# Altium schematic sheet RC96_Tone.SchDoc
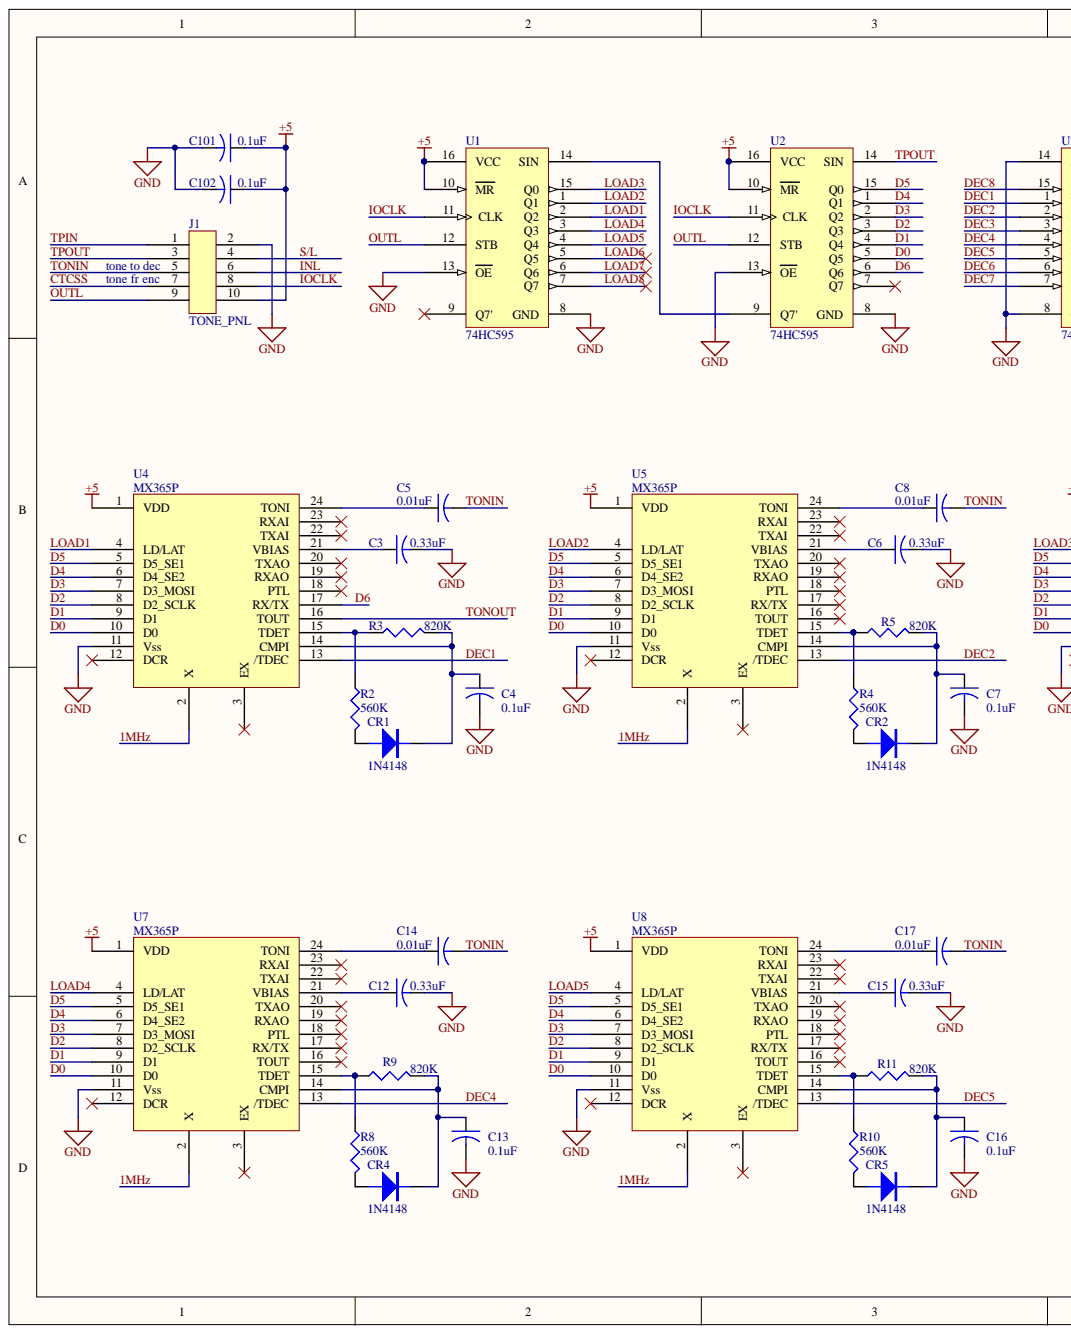
[diagram p0: RC96_Tone.SchDoc, left]
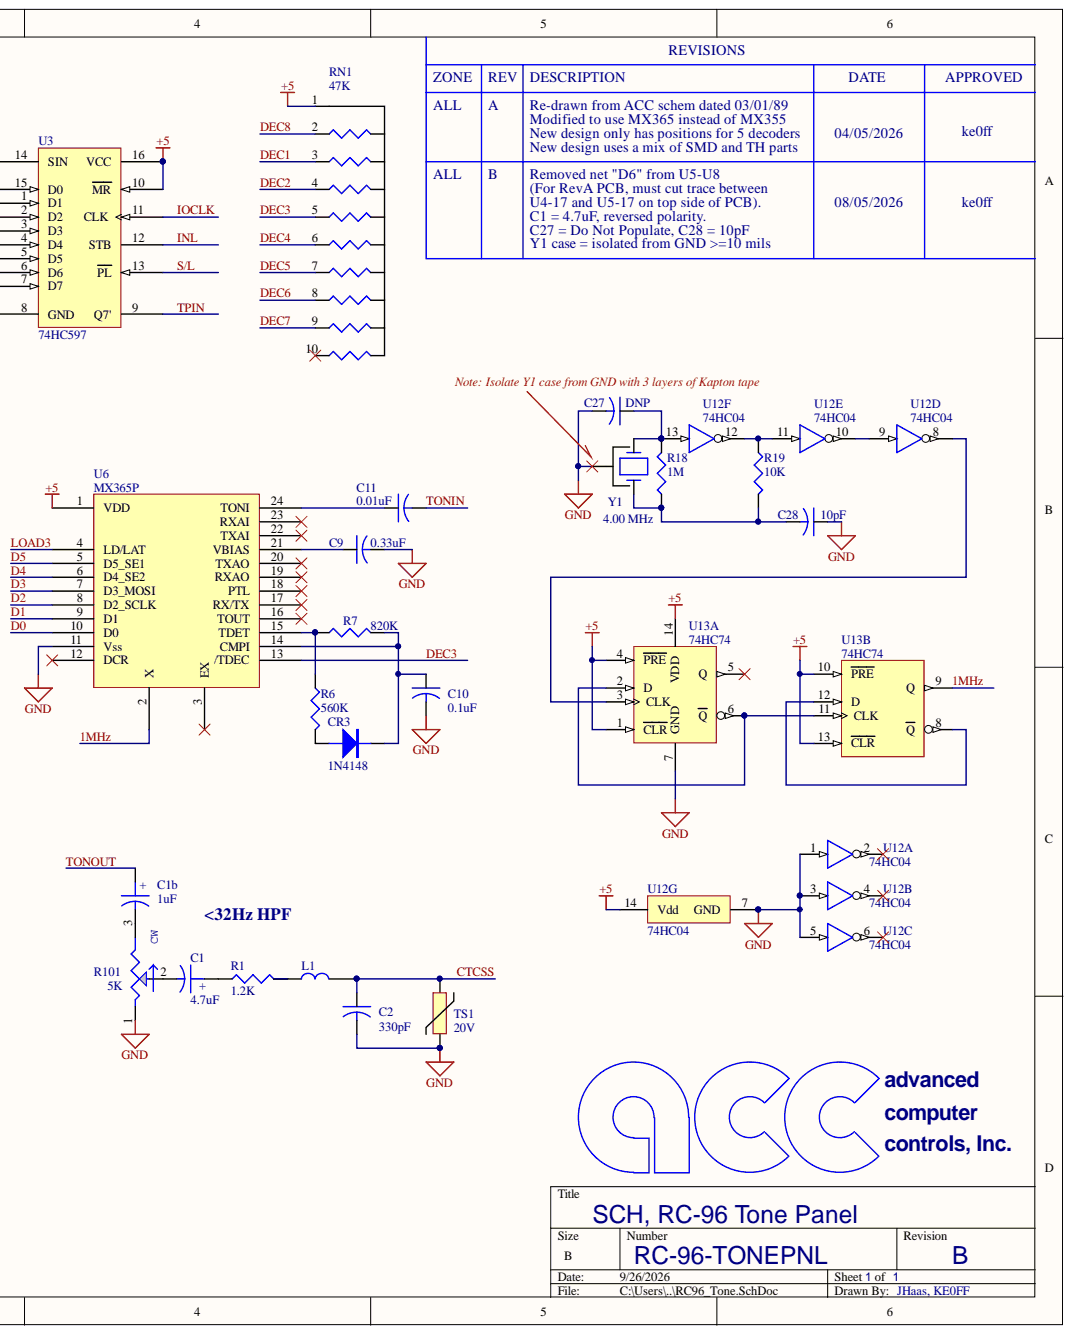
[diagram p1: RC96_Tone.SchDoc, right]

## Parts
C1  4.7uF  [RB.08/.2]
C1b  1uF  [RB.08/.2]
C2  330pF  [0603]
C3  0.33uF  [1206]
C4  0.1uF  [0805]
C5  0.01uF  [0805]
C6  0.33uF  [1206]
C7  0.1uF  [0805]
C8  0.01uF  [0805]
C9  0.33uF  [1206]
C10  0.1uF  [0805]
C11  0.01uF  [0805]
C12  0.33uF  [1206]
C13  0.1uF  [0805]
C14  0.01uF  [0805]
C15  0.33uF  [1206]
C16  0.1uF  [0805]
C17  0.01uF  [0805]
C27  DNP  [0603]
C28  10pF  [0603]
C101  0.1uF  [0805]
C102  0.1uF  [0805]
CR1  1N4148  [AXIAL2]
CR2  1N4148  [AXIAL2]
CR3  1N4148  [AXIAL2]
CR4  1N4148  [AXIAL2]
CR5  1N4148  [AXIAL2]
J1  TONE_PNL  [HDR2X5]
L1  120ohm  [AXIAL5]  (value not drawn)
R1  1.2K  [0603]
R2  560K  [0603]
R3  820K  [0603]
R4  560K  [0603]
R5  820K  [0603]
R6  560K  [0603]
R7  820K  [0603]
R8  560K  [0603]
R9  820K  [0603]
R10  560K  [0603]
R11  820K  [0603]
R18  1M  [AXIAL3]
R19  10K  [0603]
R101  5K  [POT_3362H]
RN1  47K  [SIP10]
TS1  20V  [RAD2]
U1  74HC595  [DIP16]
U2  74HC595  [DIP16]
U3  74HC597  [DIP16]
U4  MX365P  [DIP24]
U5  MX365P  [DIP24]
U6  MX365P  [DIP24]
U7  MX365P  [DIP24]
U8  MX365P  [DIP24]
U12  74HC04  [DIP14]  (parts A,B,C,D,E,F,G)
U13  74HC74  [DIP14]  (parts A,B)
Y1  4.00 MHz  [XTAL_AT49_HYB]
  ACC_LOGO  [ACC_LOGO]  (value not drawn)  (no BOM)

## Nets
+5: C101.1 C102.1 J1.10 RN1.1 U1.10(MR) U1.16(VCC) U2.10(MR) U2.16(VCC) U3.10(MR) U3.16(VCC) U4.1(VDD) U5.1(VDD) U6.1(VDD) U7.1(VDD) U8.1(VDD) U12.14(Vdd) U13.1(CLR) U13.4(PRE) U13.10(PRE) U13.13(CLR) U13.14(VDD)
GND: C2.2 C3.2 C4.2 C6.2 C7.2 C9.2 C10.2 C12.2 C13.2 C15.2 C16.2 C27.2 C28.1 C101.2 C102.2 J1.2 R101.1 TS1.2 U1.8(GND) U1.13(OE) U2.8(GND) U2.13(OE) U3.8(GND) U3.14(SIN) U4.11(Vss) U5.11(Vss) U6.11(Vss) U7.11(Vss) U8.11(Vss) U12.1 U12.3 U12.5 U12.7(GND) U13.7(GND) Y1.3
1MHZ: U4.2(X) U5.2(X) U6.2(X) U7.2(X) U8.2(X) U13.9(Q)
CTCSS: C2.1 J1.7 L1.2 TS1.1
D0: U2.5(Q5) U4.10(D0) U5.10(D0) U6.10(D0) U7.10(D0) U8.10(D0)
D1: U2.4(Q4) U4.9(D1) U5.9(D1) U6.9(D1) U7.9(D1) U8.9(D1)
D2: U2.3(Q3) U4.8(D2_SCLK) U5.8(D2_SCLK) U6.8(D2_SCLK) U7.8(D2_SCLK) U8.8(D2_SCLK)
D3: U2.2(Q2) U4.7(D3_MOSI) U5.7(D3_MOSI) U6.7(D3_MOSI) U7.7(D3_MOSI) U8.7(D3_MOSI)
D4: U2.1(Q1) U4.6(D4_SE2) U5.6(D4_SE2) U6.6(D4_SE2) U7.6(D4_SE2) U8.6(D4_SE2)
D5: U2.15(Q0) U4.5(D5_SE1) U5.5(D5_SE1) U6.5(D5_SE1) U7.5(D5_SE1) U8.5(D5_SE1)
D6: U2.6(Q6) U4.17(RX/TX)
DEC1: RN1.3 U3.1(D1) U4.13(/TDEC)
DEC2: RN1.4 U3.2(D2) U5.13(/TDEC)
DEC3: RN1.5 U3.3(D3) U6.13(/TDEC)
DEC4: RN1.6 U3.4(D4) U7.13(/TDEC)
DEC5: RN1.7 U3.5(D5) U8.13(/TDEC)
DEC6: RN1.8 U3.6(D6)
DEC7: RN1.9 U3.7(D7)
DEC8: RN1.2 U3.15(D0)
INL: J1.6 U3.12(STB)
IOCLK: J1.8 U1.11(CLK) U2.11(CLK) U3.11(CLK)
LOAD1: U1.2(Q2) U4.4(LD/LAT)
LOAD2: U1.1(Q1) U5.4(LD/LAT)
LOAD3: U1.15(Q0) U6.4(LD/LAT)
LOAD4: U1.3(Q3) U7.4(LD/LAT)
LOAD5: U1.4(Q4) U8.4(LD/LAT)
LOAD6: U1.5(Q5)
LOAD7: U1.6(Q6)
LOAD8: U1.7(Q7)
OUTL: J1.9 U1.12(STB) U2.12(STB)
S/L: J1.4 U3.13(PL)
TONIN: C5.2 C8.2 C11.2 C14.2 C17.2 J1.5
TONOUT: C1b.1 U4.16(TOUT)
TPIN: J1.1 U3.9(Q7')
TPOUT: J1.3 U2.14(SIN)
NetC1_1: C1.1 R1.1
NetC1_2: C1.2 R101.2
NetC1b_2: C1b.2 R101.3
NetC3_1: C3.1 U4.21(VBIAS)
NetC4_1: C4.1 CR1.1 R3.2 U4.14(CMPI)
NetC5_1: C5.1 U4.24(TONI)
NetC6_1: C6.1 U5.21(VBIAS)
NetC7_1: C7.1 CR2.1 R5.2 U5.14(CMPI)
NetC8_1: C8.1 U5.24(TONI)
NetC9_1: C9.1 U6.21(VBIAS)
NetC10_1: C10.1 CR3.1 R7.2 U6.14(CMPI)
NetC11_1: C11.1 U6.24(TONI)
NetC12_1: C12.1 U7.21(VBIAS)
NetC13_1: C13.1 CR4.1 R9.2 U7.14(CMPI)
NetC14_1: C14.1 U7.24(TONI)
NetC15_1: C15.1 U8.21(VBIAS)
NetC16_1: C16.1 CR5.1 R11.2 U8.14(CMPI)
NetC17_1: C17.1 U8.24(TONI)
NetC27_1: C27.1 R18.1 U12.13 Y1.2
NetC28_2: C28.2 R18.2 R19.2 Y1.1
NetCR1_2: CR1.2 R2.2
NetCR2_2: CR2.2 R4.2
NetCR3_2: CR3.2 R6.2
NetCR4_2: CR4.2 R8.2
NetCR5_2: CR5.2 R10.2
NetL1_1: L1.1 R1.2
NetR2_1: R2.1 R3.1 U4.15(TDET)
NetR4_1: R4.1 R5.1 U5.15(TDET)
NetR6_1: R6.1 R7.1 U6.15(TDET)
NetR8_1: R8.1 R9.1 U7.15(TDET)
NetR10_1: R10.1 R11.1 U8.15(TDET)
NetR19_1: R19.1 U12.11 U12.12
NetU1_14: U1.14(SIN) U2.9(Q7')
NetU12_8: U12.8 U13.3(CLK)
NetU12_9: U12.9 U12.10
NetU13_2: U13.2(D) U13.6(Q) U13.11(CLK)
NetU13_8: U13.8(Q) U13.12(D)

Not connected: RN1 10; U1 9(Q7'); U2 7(Q7); U4 3(EX) 12(DCR) 18(PTL) 19(RXAO) 20(TXAO) 22(TXAI) 23(RXAI); U5 3(EX) 12(DCR) 16(TOUT) 17(RX/TX) 18(PTL) 19(RXAO) 20(TXAO) 22(TXAI) 23(RXAI); U6 3(EX) 12(DCR) 16(TOUT) 17(RX/TX) 18(PTL) 19(RXAO) 20(TXAO) 22(TXAI) 23(RXAI); U7 3(EX) 12(DCR) 16(TOUT) 17(RX/TX) 18(PTL) 19(RXAO) 20(TXAO) 22(TXAI) 23(RXAI); U8 3(EX) 12(DCR) 16(TOUT) 17(RX/TX) 18(PTL) 19(RXAO) 20(TXAO) 22(TXAI) 23(RXAI); U12 2 4 6; U13 5(Q)

## Library data of the parts
C1 = CAP ELECTROLYTIC: CAP, ELYT, RAD, 0.1LS, 35V, 4.7uF; ALT-MPN: -; Component Kind: Standard; DNP: -; MFR: -; MPN: -; NOTES: -; NOTES2: -; PRI-MPN: -; Library Name: Galaxy SCH-jem-1.08.SchLib
C1b = CAP ELECTROLYTIC: CAP, ELYT, RAD, 0.1LS, 35V, 1.0uF; ALT-MPN: -; Component Kind: Standard; DNP: -; MFR: -; MPN: -; NOTES: -; NOTES2: -; PRI-MPN: -; Library Name: Galaxy SCH-jem-1.08.SchLib
C2-C17 C27 C28 C101 C102 = CAP 0805: CAP, TANT, 0805, 50V, 1uF; ALT-MFR: -; ALT-MPN: -; Component Kind: Standard; DNP: -; MFR: -; MPN: -; Library Name: Galaxy SCH-jem-1.08.SchLib
CR1-CR5 = DIODE-AXIAL: DIO, SI, AXIAL, 30V; ALT-MPN: -; Component Kind: Standard; DNP: -; MFR: -; MPN: -; NOTES: -; NOTES2: -; PRI-MPN: -; Library Name: Galaxy SCH-jem-1.08.SchLib
J1 = CON_10P_050: CON, DIP, 10 POS, 0.05" LS; ALT-MPN: -; Component Kind: Standard; DNP: -; MFR: -; MPN: -; NOTES: -; NOTES2: -; PRI-MPN: -; Library Name: Galaxy SCH-jem-1.08.SchLib
L1 = FB: BD, FRT, SMD; ALT-MPN: -; Component Kind: Standard; DNP: -; MFR: -; MPN: -; NOTES: -; NOTES2: -; PRI-MPN: -; Library Name: Galaxy SCH-jem-1.08.SchLib
R1-R11 R18 R19 = R0805: RES, CHP, 0201, 1%, 4.7K; ALT-MPN: -; Component Kind: Standard; DNP: -; MFR: -; MPN: -; NOTES: -; NOTES2: -; PRI-MPN: -; Library Name: Galaxy SCH-jem-1.08.SchLib
R101 = RESISTOR VAR: RES, VAR; ALT-MPN: -; Component Kind: Standard; DNP: -; MFR: -; MPN: -; NOTES: -; NOTES2: -; PRI-MPN: -; Library Name: Galaxy SCH-jem-1.08.SchLib
RN1 = RP9: RES, NET, SIP, 9RES; ALT-MPN: -; Component Kind: Standard; DNP: -; MFR: -; MPN: -; NOTES: -; NOTES2: -; PRI-MPN: -; Library Name: Galaxy SCH-jem-1.08.SchLib
TS1 = MOV: MOV, TH, 20V; ALT-MPN: -; Component Kind: Standard; DNP: -; MFR: -; MPN: -; NOTES: -; NOTES2: -; PRI-MPN: -; Library Name: Galaxy SCH-jem-1.08.SchLib
U1 U2 = 74HC595: IC, LGC, SR, PIN, OCTL; MFR: TI; MPN: CD74HC595E; ALT-MPN: -; Component Kind: Standard; DNP: -; NOTES: -; NOTES2: -; PRI-MPN: -; Library Name: Galaxy SCH-jem-1.08.SchLib
U3 = 74HC597: IC, LGC, SR, PIN, OCTL; MFR: TI; MPN: CD74HC597EE4; ALT-MPN: -; Component Kind: Standard; DNP: -; NOTES: -; NOTES2: -; PRI-MPN: -; Library Name: Galaxy SCH-jem-1.08.SchLib
U4-U8 = MX365: IC, CTCSS, ENC/DEC; MFR: MXCOM; ALT-MPN: -; Component Kind: Standard; DNP: -; MPN: MX365P; NOTES: -; NOTES2: -; PRI-MPN: -; Library Name: Galaxy SCH-jem-1.08.SchLib
U12 = 74HC04: IC, LGC, NOT, HEX; ALT-MPN: -; Component Kind: Standard; DNP: -; MFR: -; MPN: -; NOTES: -; NOTES2: -; PRI-MPN: -; Library Name: Galaxy SCH-jem-1.08.SchLib
U13 = 74HC74: IC, FF, D, PET, FF, RS, CLR; ALT-MPN: -; Component Kind: Standard; DNP: -; MFR: -; MPN: -; NOTES: -; NOTES2: -; PRI-MPN: -; Library Name: Galaxy SCH-jem-1.08.SchLib
Y1 = CRYSTAL-3PIN_SH: XTAL, 3-PIN (SH); ALT-MPN: -; Component Kind: Standard; DNP: -; MFR: -; MPN: -; NOTES: -; NOTES2: -; PRI-MPN: -; Library Name: Galaxy SCH-jem-1.08.SchLib
